# Altium schematic sheet [03]-MCU.SchDoc
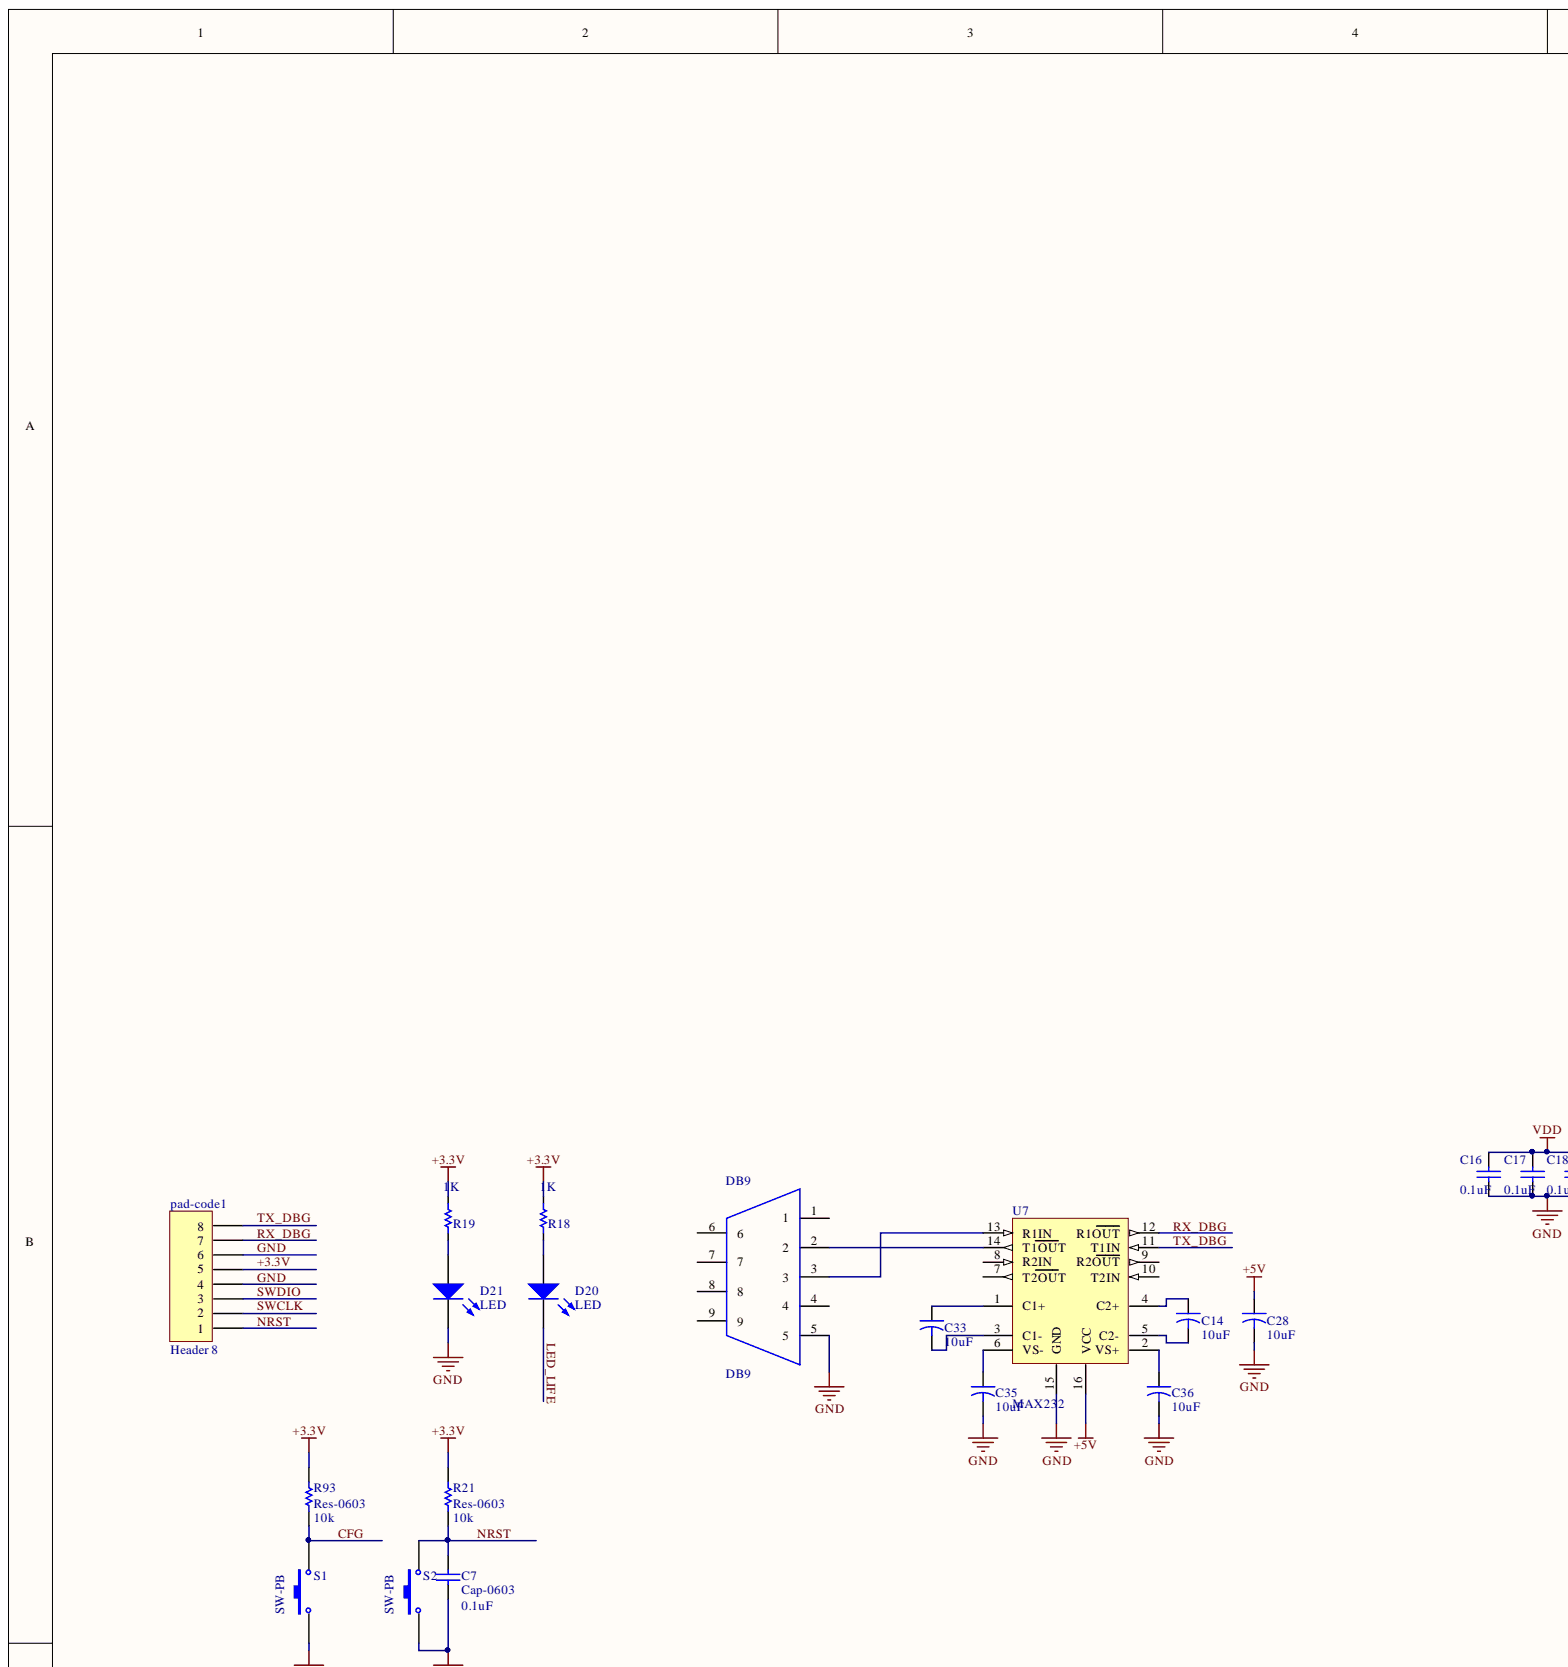
[diagram p0: [03]-MCU.SchDoc, top left]
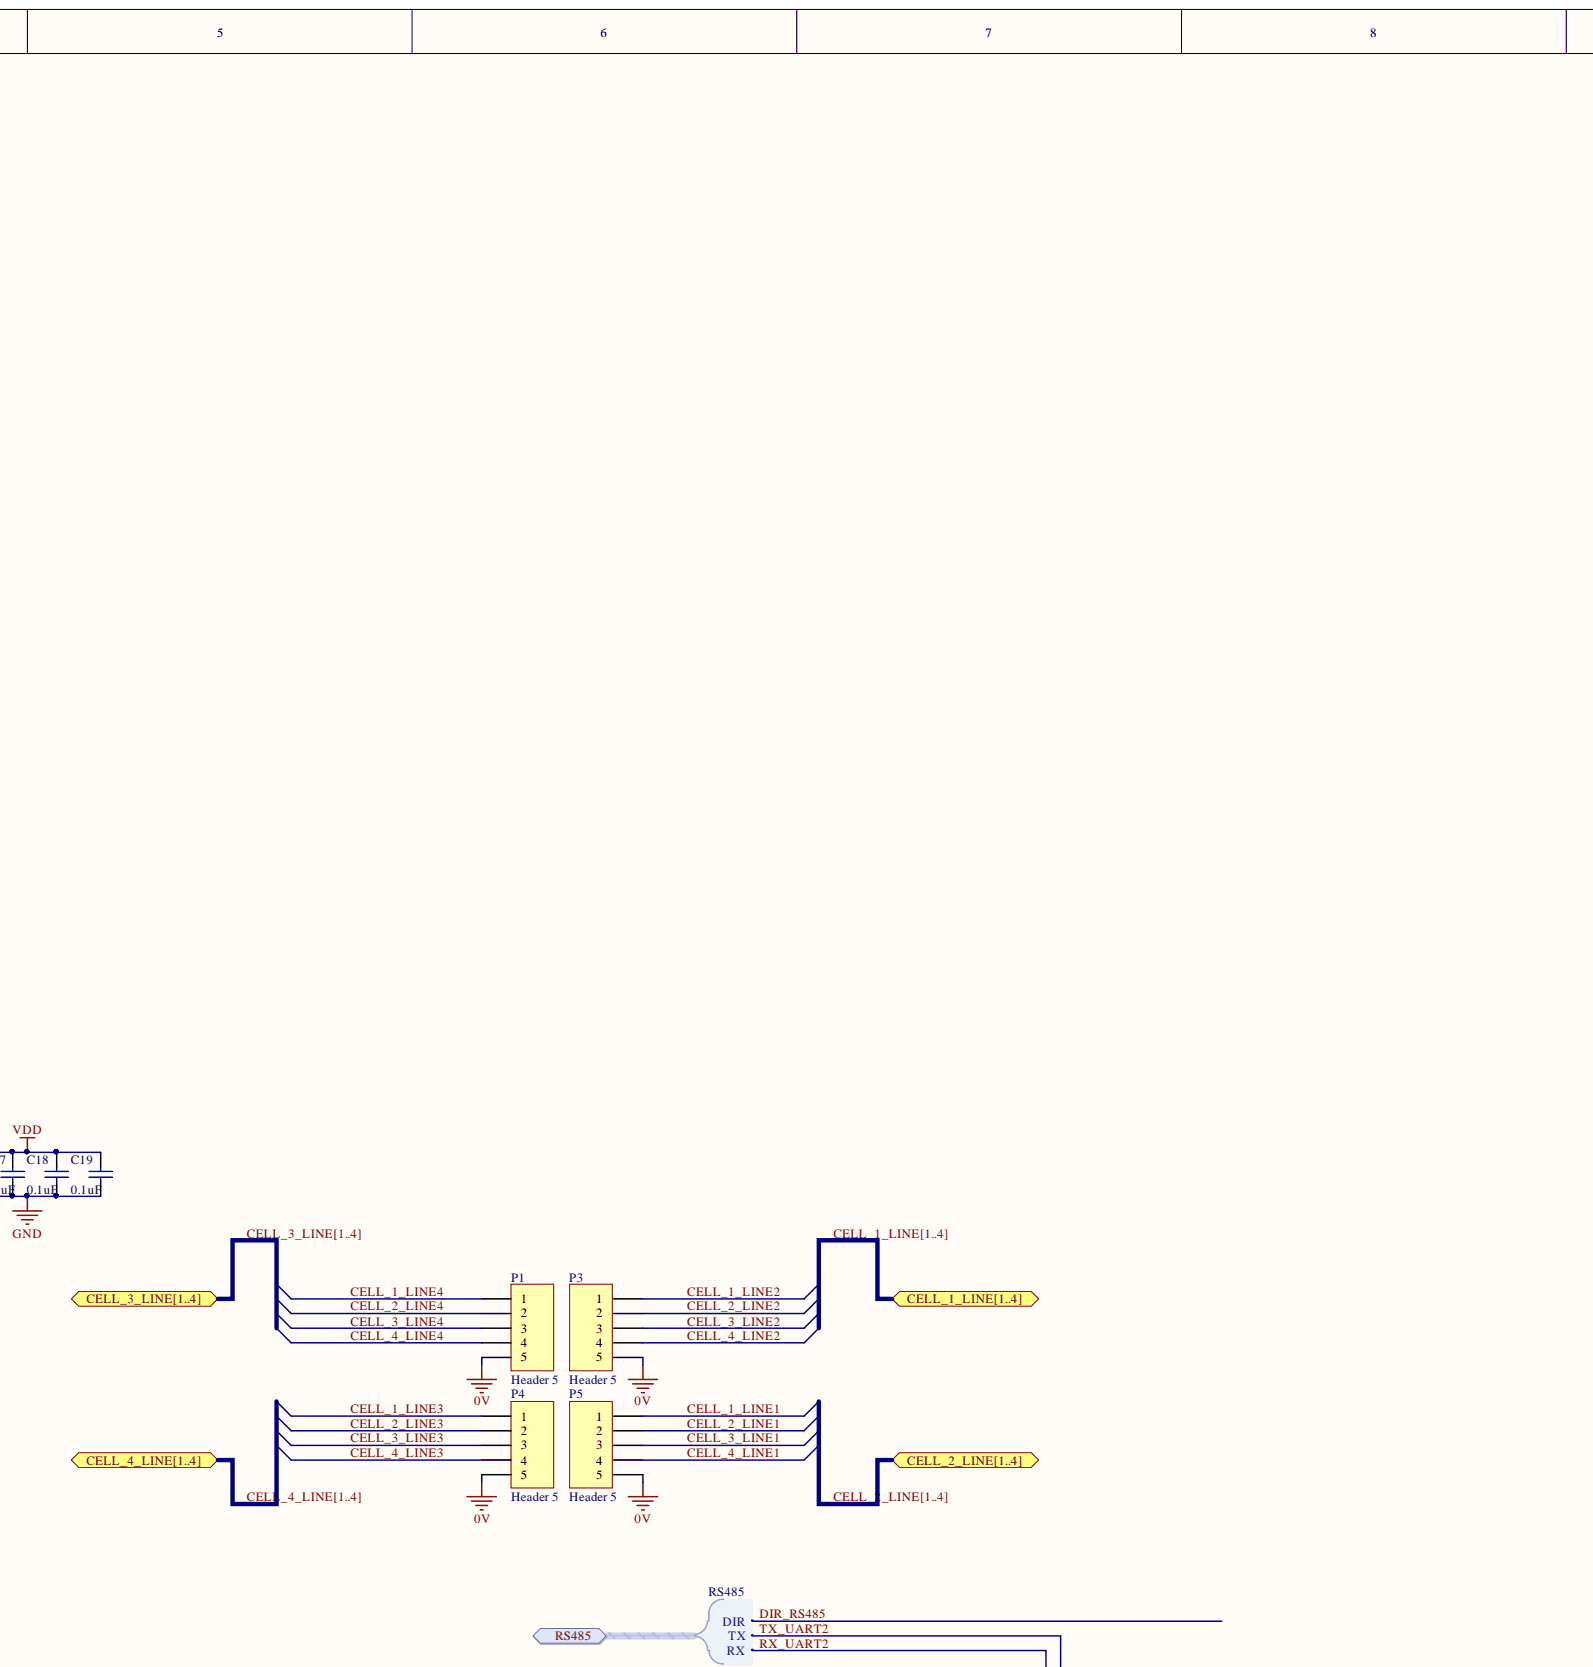
[diagram p1: [03]-MCU.SchDoc, top centre]
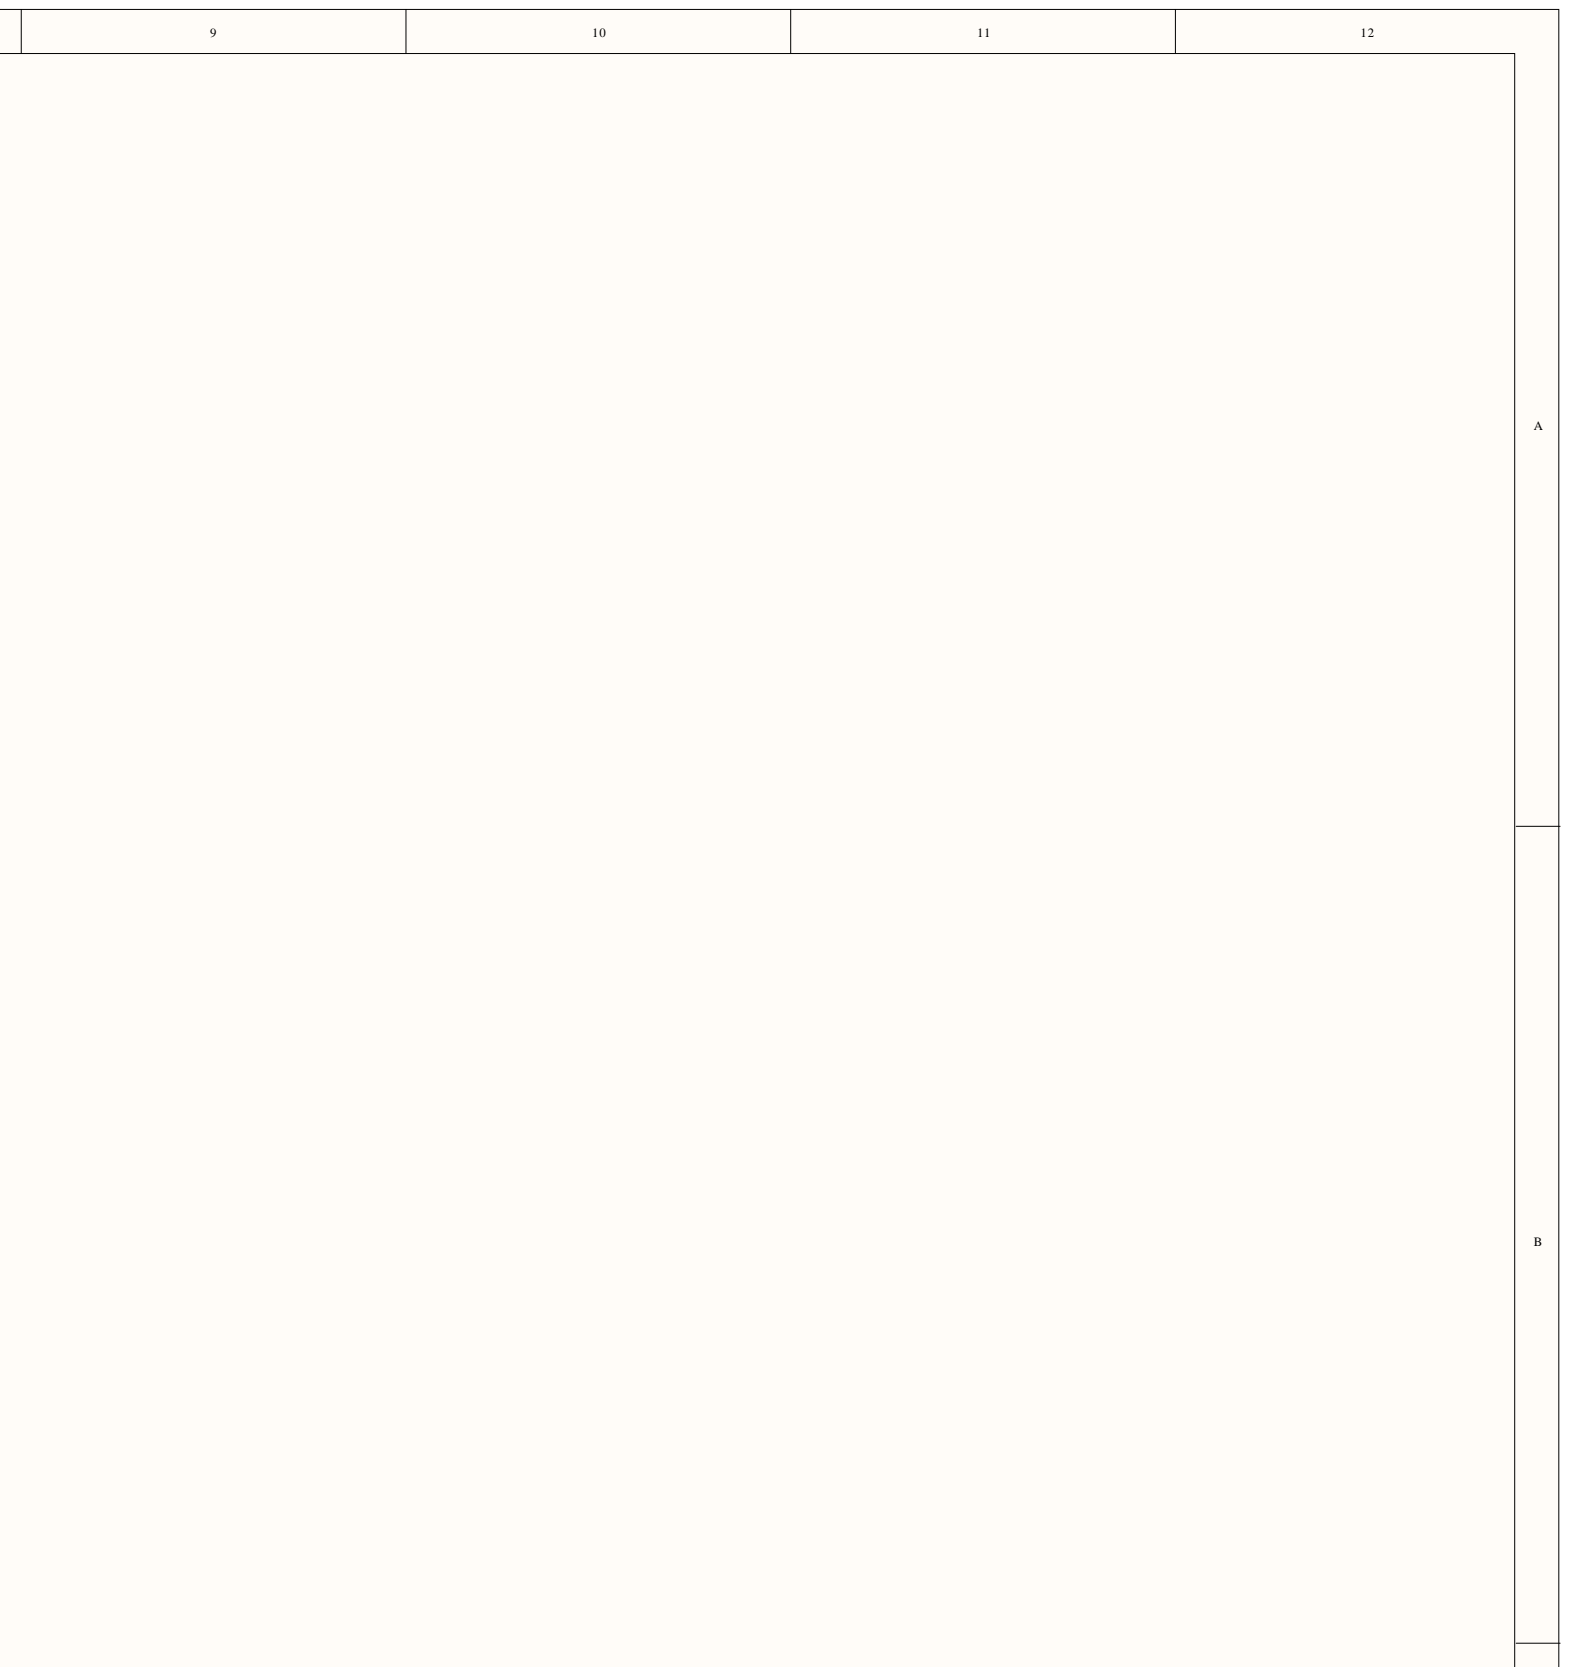
[diagram p2: [03]-MCU.SchDoc, top right]
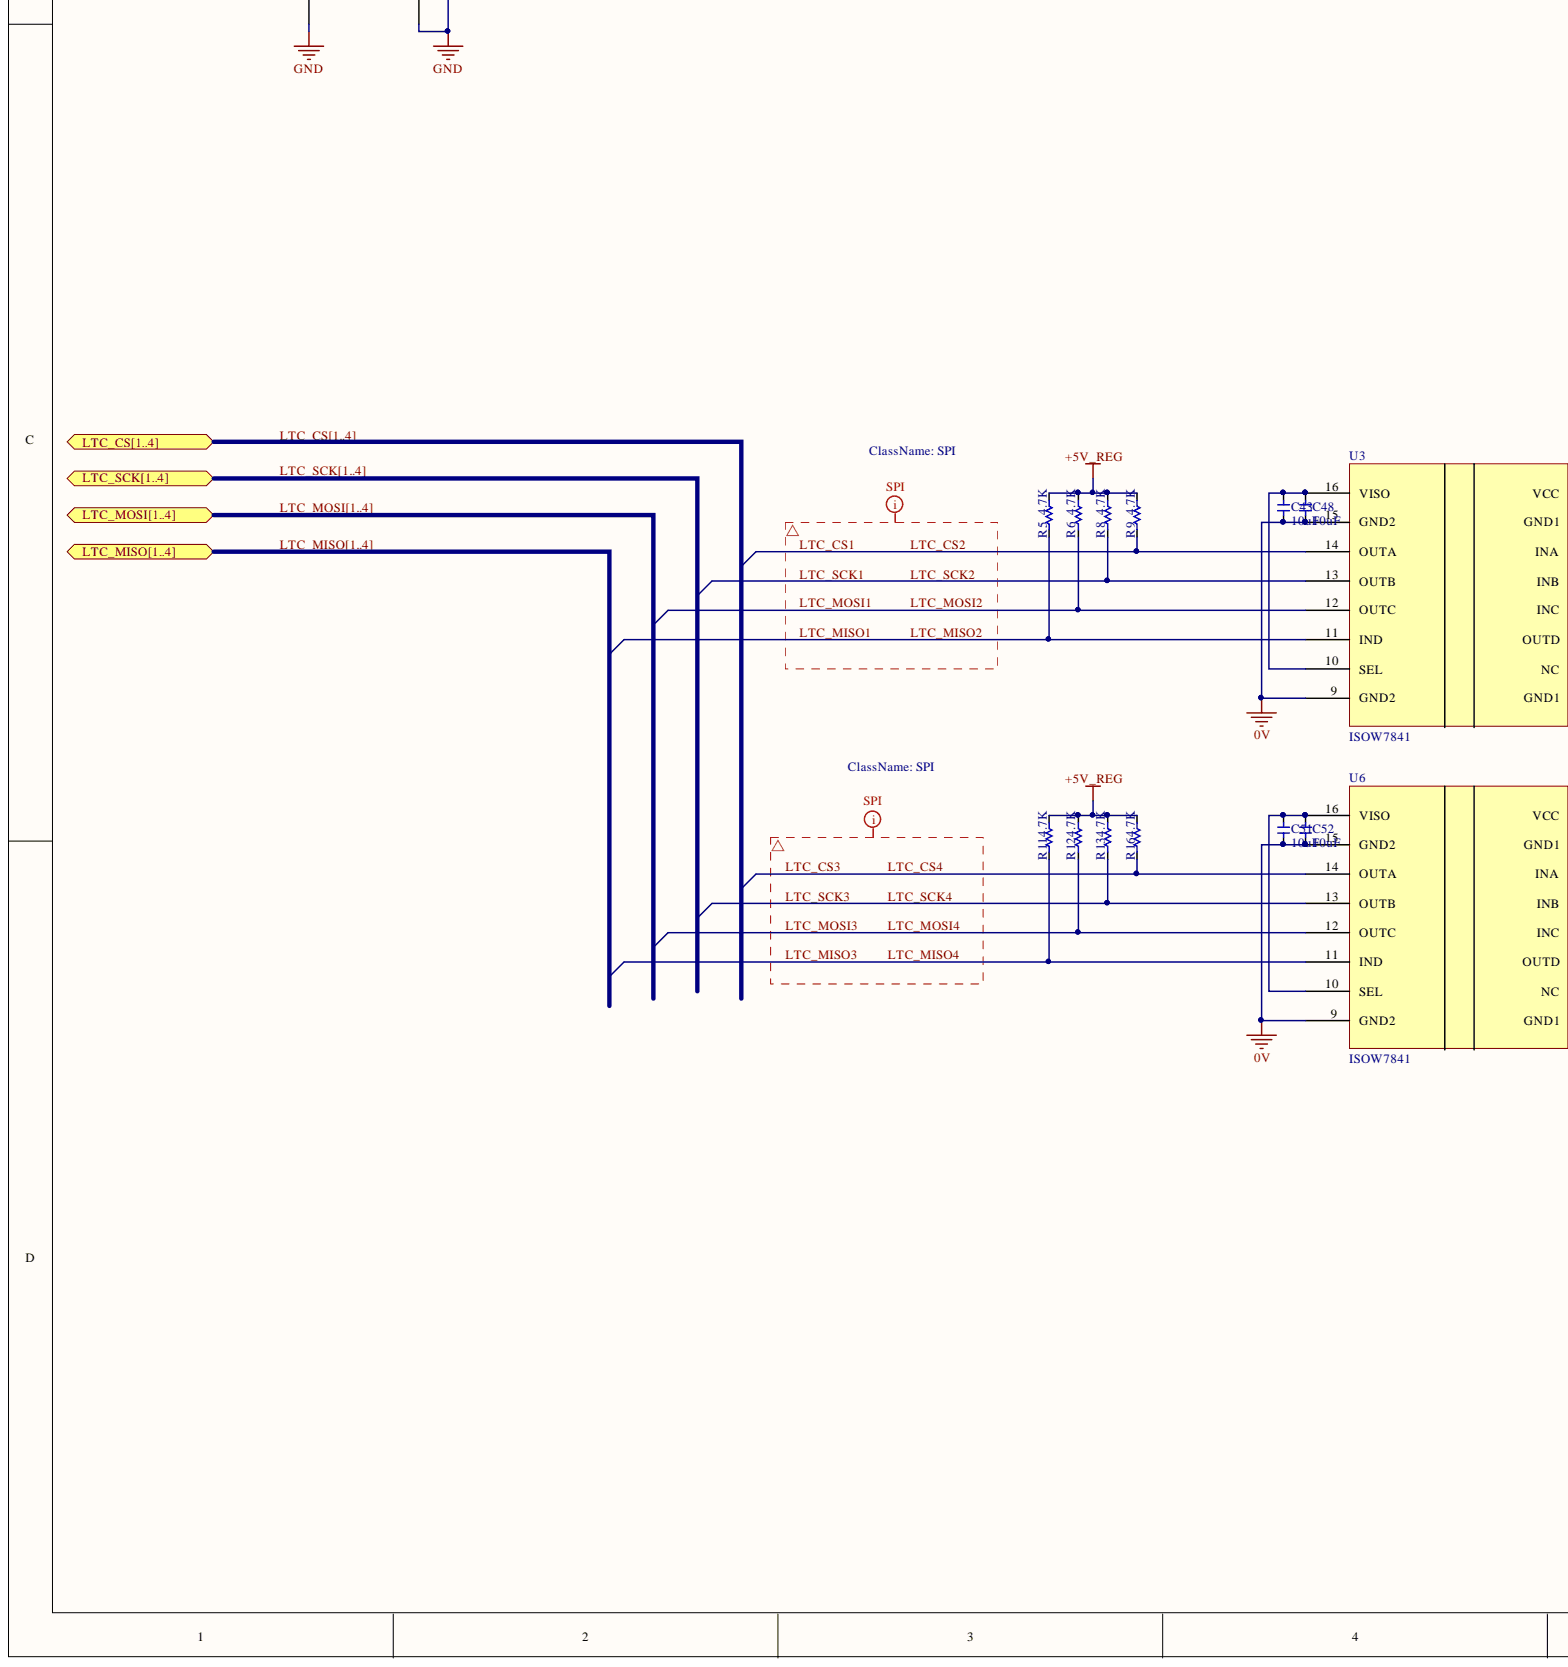
[diagram p3: [03]-MCU.SchDoc, bottom left]
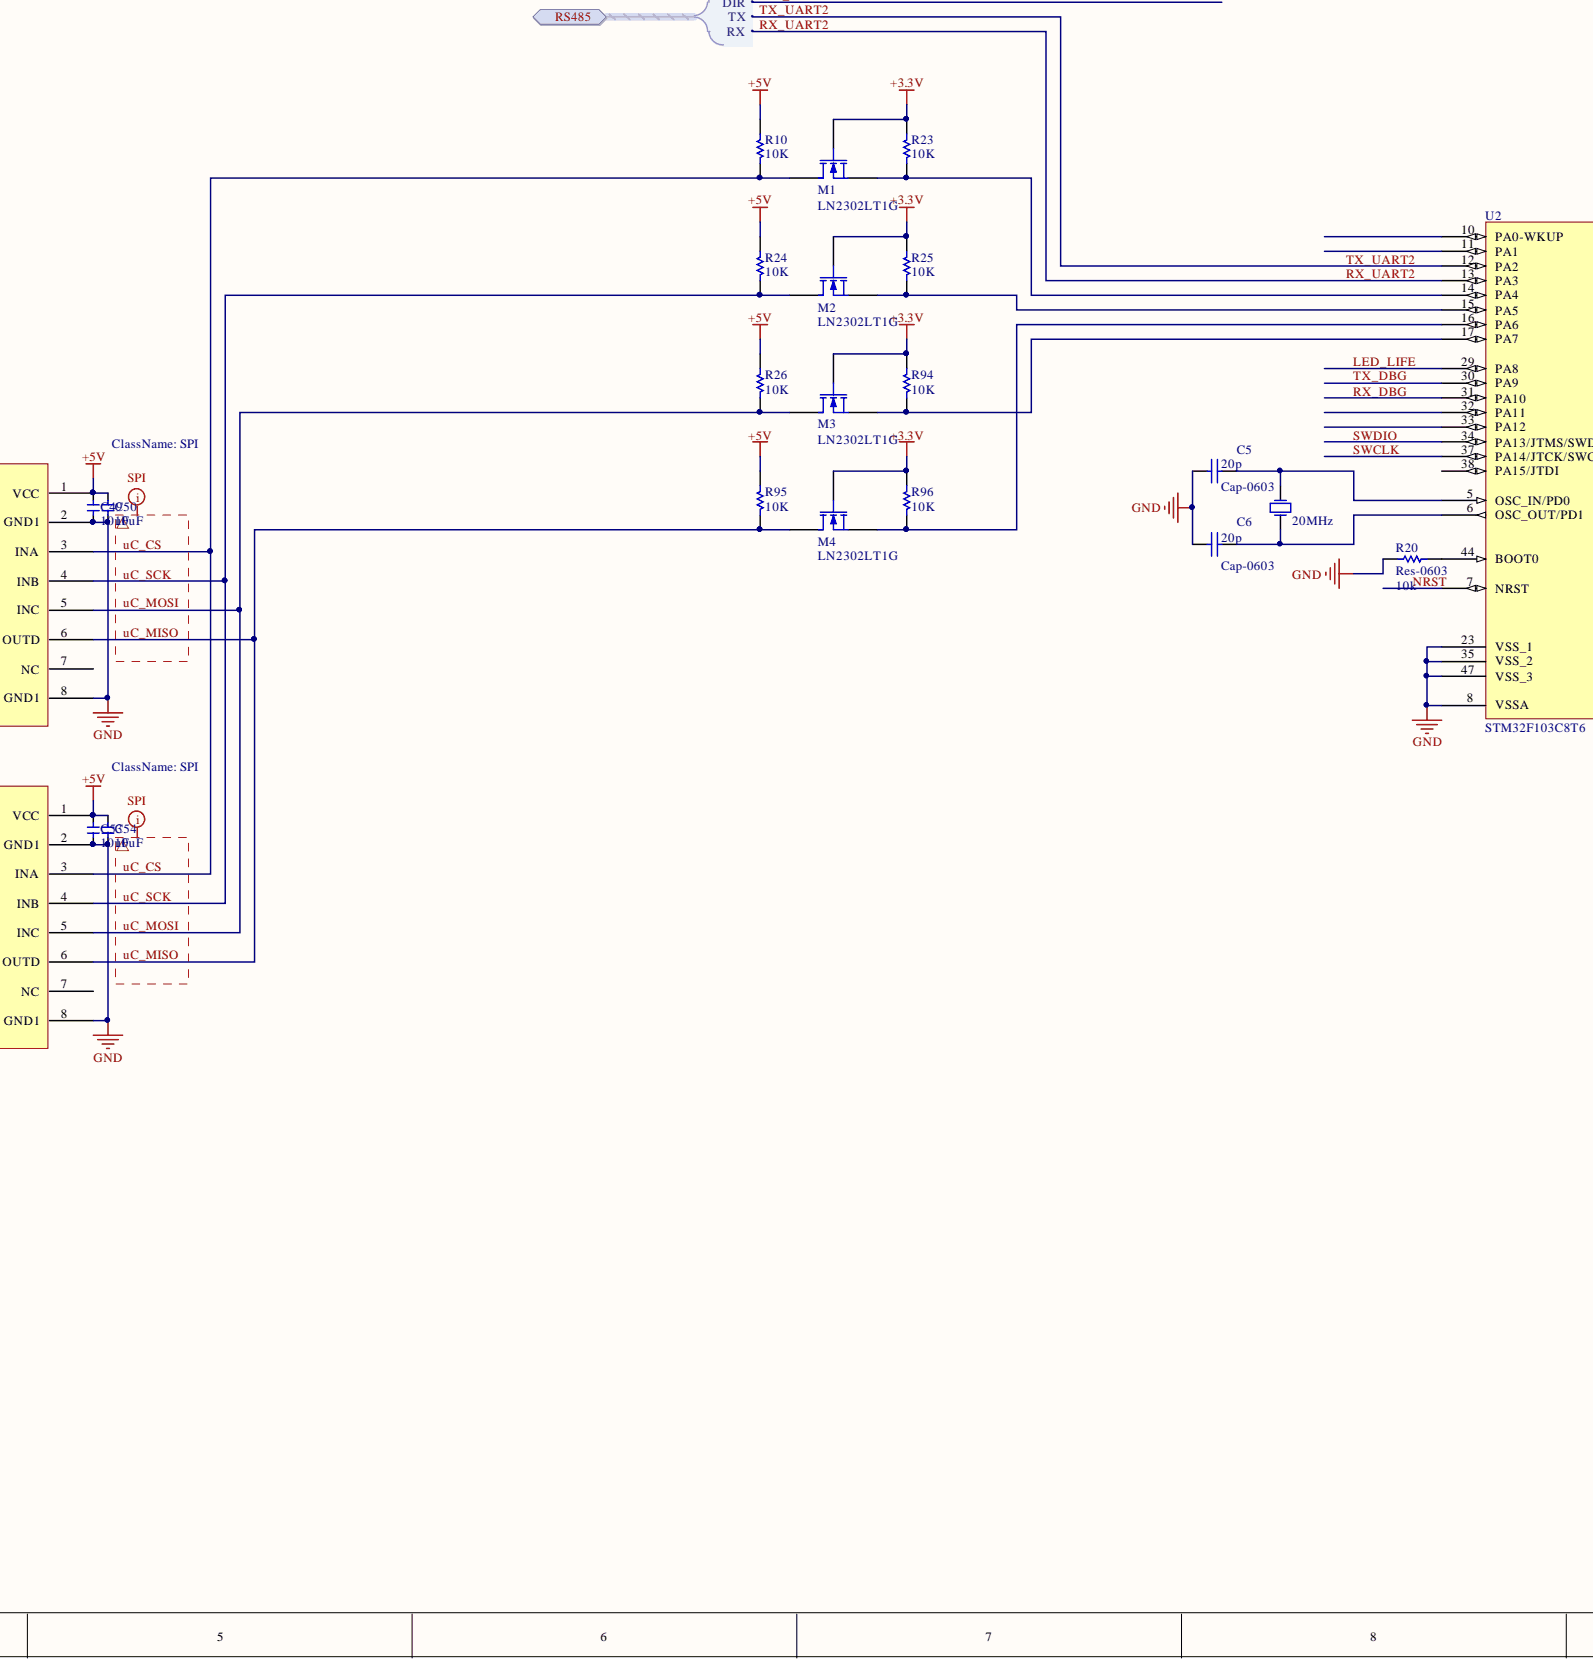
[diagram p4: [03]-MCU.SchDoc, bottom centre]
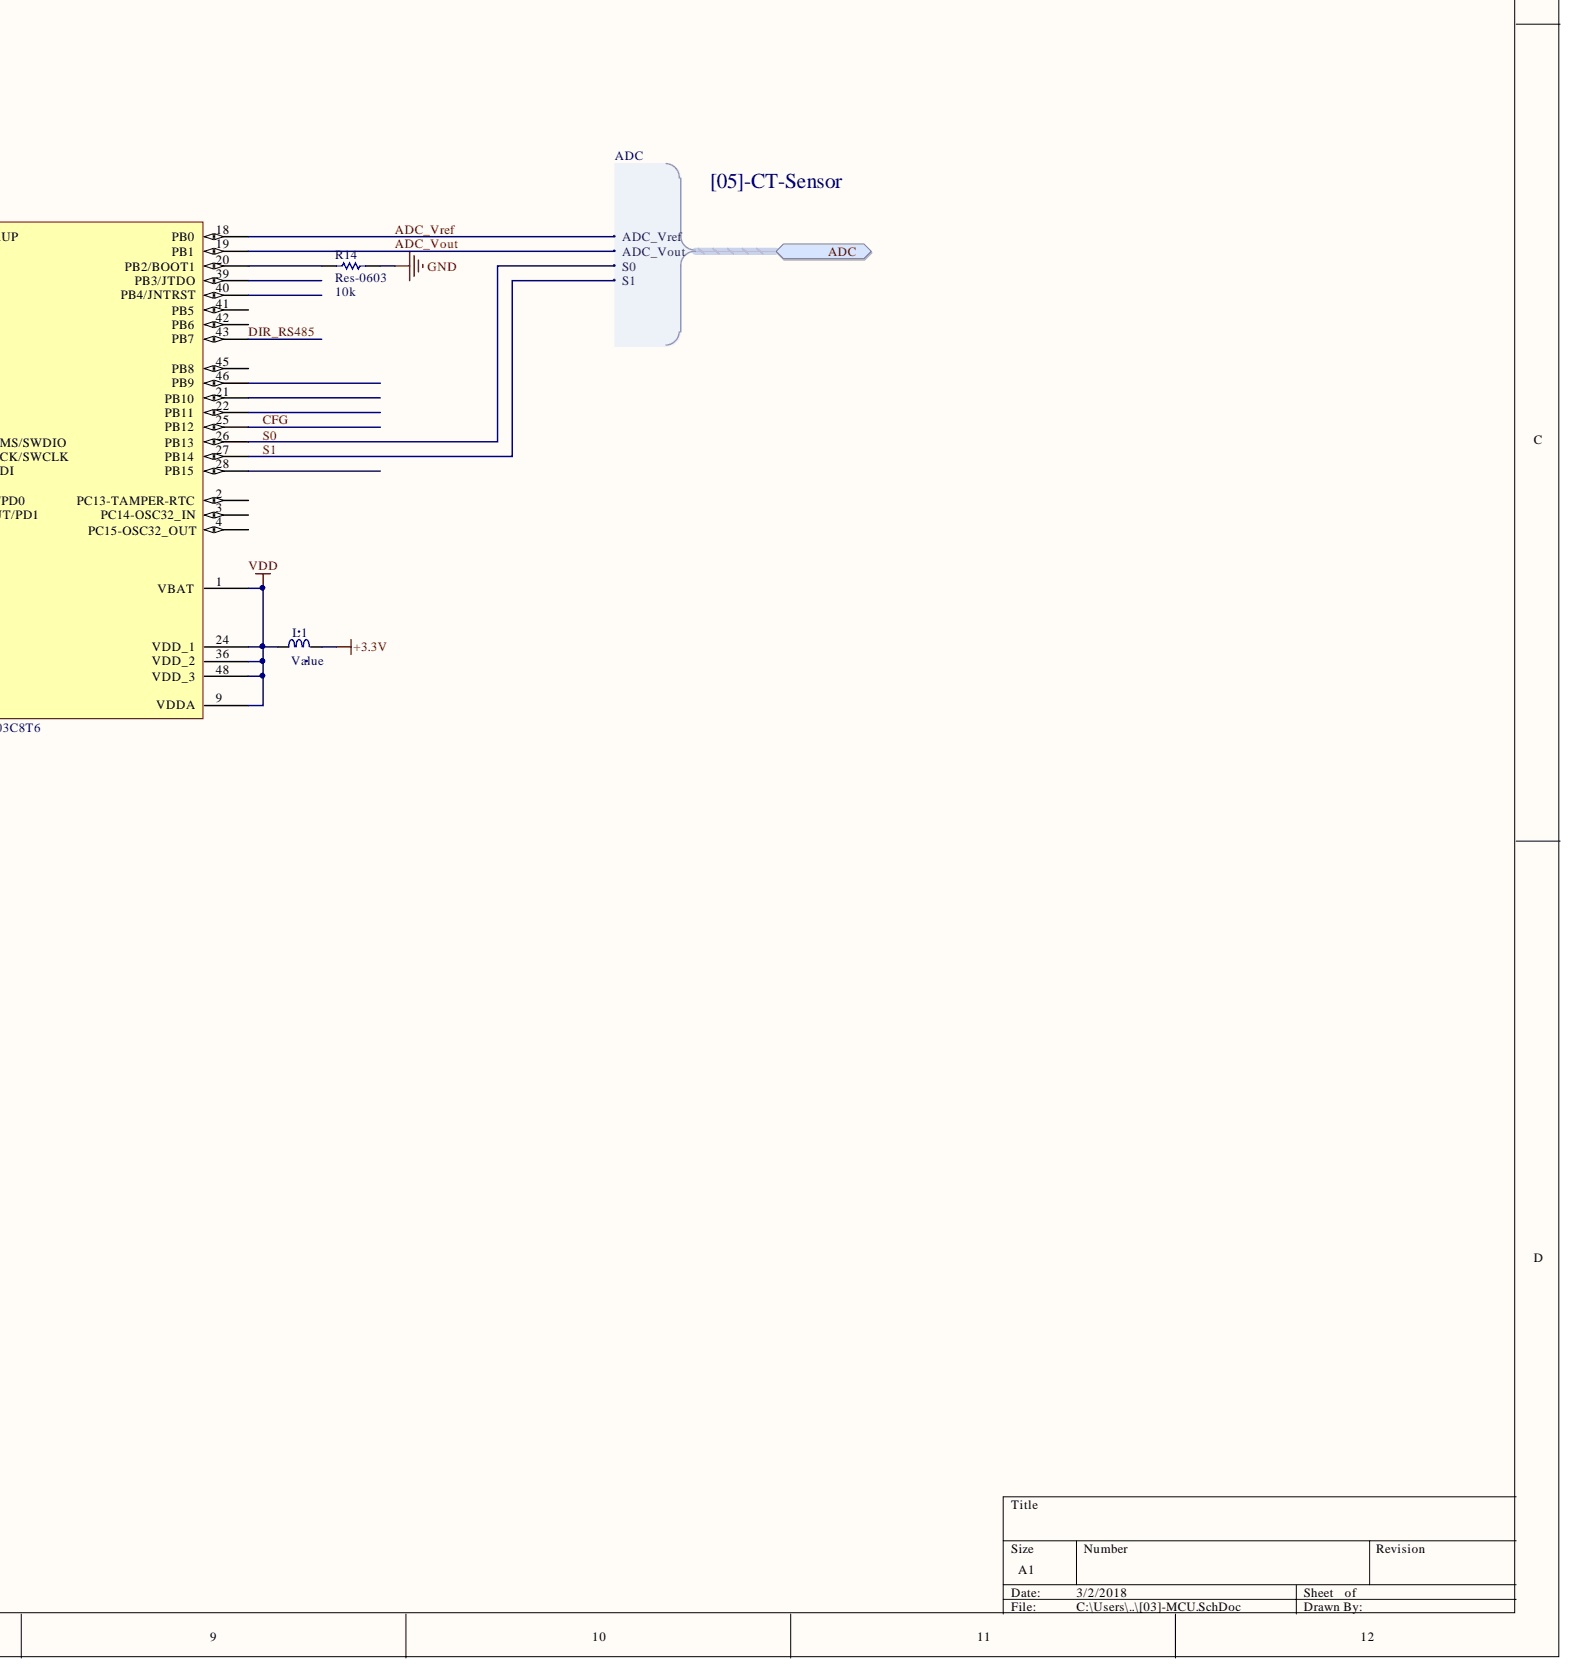
[diagram p5: [03]-MCU.SchDoc, bottom right]

## Parts
C5  Cap-0603  value 20p  [cap-0603]
C6  Cap-0603  value 20p  [cap-0603]
C7  Cap-0603  value 0.1uF  [cap-0603]
C14  10uF  [cap-0805]
C16  value 0.1uF  [cap-0402]  (hidden comment: Cap-0603)
C17  value 0.1uF  [cap-0402]  (hidden comment: Cap-0603)
C18  value 0.1uF  [cap-0402]  (hidden comment: Cap-0603)
C19  value 0.1uF  [cap-0402]  (hidden comment: Cap-0603)
C28  10uF  [cap-0805]
C33  10uF  [cap-0805]
C35  10uF  [cap-0805]
C36  10uF  [cap-0805]
C43  10uF  [cap-0603]
C48  10uF  [cap-0603]
C49  10uF  [cap-0603]
C50  10uF  [cap-0603]
C51  10uF  [cap-0603]
C52  10uF  [cap-0603]
C53  10uF  [cap-0603]
C54  10uF  [cap-0603]
D20  LED  [LED_SMD_0805_BLUE]
D21  LED  [LED_SMD_0805_BLUE]
DB9  DB9  [DB9]
L1  Value  [Inductor-1206]
M1  LN2302LT1G  [SOT-23B_L]
M2  LN2302LT1G  [SOT-23B_L]
M3  LN2302LT1G  [SOT-23B_L]
M4  LN2302LT1G  [SOT-23B_L]
P1  Header 5  [TBlock5-5.08]
P3  Header 5  [TBlock5-5.08]
P4  Header 5  [TBlock5-5.08]
P5  Header 5  [TBlock5-5.08]
pad-code1  Header 8  [HDR1X8]
R5  4.7K  [res-0603]
R6  4.7K  [res-0603]
R8  4.7K  [res-0603]
R9  4.7K  [res-0603]
R10  value 10K  [res-0603]  (hidden comment: Res-0603)
R11  4.7K  [res-0603]  (value not drawn)
R12  4.7K  [res-0603]  (value not drawn)
R13  4.7K  [res-0603]  (value not drawn)
R14  Res-0603  value 10k  [res-0603]
R16  4.7K  [res-0603]  (value not drawn)
R18  1K  [res-0603]
R19  1K  [res-0603]
R20  Res-0603  value 10k  [res-0603]
R21  Res-0603  value 10k  [res-0603]
R23  value 10K  [res-0603]  (hidden comment: Res-0603)
R24  value 10K  [res-0603]  (hidden comment: Res-0603)
R25  value 10K  [res-0603]  (hidden comment: Res-0603)
R26  value 10K  [res-0603]  (hidden comment: Res-0603)
R93  Res-0603  value 10k  [res-0603]
R94  value 10K  [res-0603]  (hidden comment: Res-0603)
R95  value 10K  [res-0603]  (hidden comment: Res-0603)
R96  value 10K  [res-0603]  (hidden comment: Res-0603)
S1  SW-PB  [SW-RST]
S2  SW-PB  [SW-RST]
U2  STM32F103C8T6  [STM-LQFP48_N]
U3  ISOW7841  [ISOW7841]
U6  ISOW7841  [ISOW7841]
U7  MAX232  [SO16]
Y1  20MHz  [XTAL1140X470X160N]

## Ports
ADC, ADC.ADC_VOUT, ADC.ADC_VREF, ADC.S0, ADC.S1, CELL_1_LINE1, CELL_1_LINE2, CELL_1_LINE3, CELL_1_LINE4, CELL_1_LINE[1..4], CELL_2_LINE1, CELL_2_LINE2, CELL_2_LINE3, CELL_2_LINE4, CELL_2_LINE[1..4], CELL_3_LINE1, CELL_3_LINE2, CELL_3_LINE3, CELL_3_LINE4, CELL_3_LINE[1..4], CELL_4_LINE1, CELL_4_LINE2, CELL_4_LINE3, CELL_4_LINE4, CELL_4_LINE[1..4], LTC_CS1, LTC_CS2, LTC_CS3, LTC_CS4, LTC_CS[1..4], LTC_MISO1, LTC_MISO2, LTC_MISO3, LTC_MISO4, LTC_MISO[1..4], LTC_MOSI1, LTC_MOSI2, LTC_MOSI3, LTC_MOSI4, LTC_MOSI[1..4], LTC_SCK1, LTC_SCK2, LTC_SCK3, LTC_SCK4, LTC_SCK[1..4], RS485, RS485.DIR, RS485.RX, RS485.TX

## Nets
0V: C43.1 C48.1 C51.1 C52.1 P1.5 P3.5 P4.5 P5.5 U3.9(GND2) U3.15(GND2) U6.9(GND2) U6.15(GND2)
+5V: C28.1 C49.2 C50.2 C53.2 C54.2 R10.2 R24.2 R26.2 R95.2 U3.1(VCC) U6.1(VCC) U7.16(VCC)
+5V_REG: R5.2 R6.2 R8.2 R9.2 R11.2 R12.2 R13.2 R16.2
VDD: C16.2 C17.2 C18.2 C19.2 L1.1 U2.1(VBAT) U2.9(VDDA) U2.24(VDD_1) U2.36(VDD_2) U2.48(VDD_3)
+3.3V: L1.2 M1.1 M2.1 M3.1 M4.1 pad-code1.5 R18.2 R19.2 R21.1 R23.2 R25.2 R93.1 R94.2 R96.2
ADC_VOUT: U2.19(PB1) ; port ADC
ADC_VREF: U2.18(PB0) ; port ADC
CELL_1_LINE1: P5.1 ; port CELL_1_LINE[1..4]  [also labelled CELL_1_LINE]
CELL_1_LINE2: P3.1 ; port CELL_1_LINE[1..4]  [also labelled CELL_1_LINE]
CELL_1_LINE3: P4.1 ; port CELL_1_LINE[1..4]  [also labelled CELL_1_LINE]
CELL_1_LINE4: P1.1 ; port CELL_1_LINE[1..4]  [also labelled CELL_1_LINE]
CELL_2_LINE1: P5.2 ; port CELL_2_LINE[1..4]  [also labelled CELL_2_LINE]
CELL_2_LINE2: P3.2 ; port CELL_2_LINE[1..4]  [also labelled CELL_2_LINE]
CELL_2_LINE3: P4.2 ; port CELL_2_LINE[1..4]  [also labelled CELL_2_LINE]
CELL_2_LINE4: P1.2 ; port CELL_2_LINE[1..4]  [also labelled CELL_2_LINE]
CELL_3_LINE1: P5.3 ; port CELL_3_LINE[1..4]  [also labelled CELL_3_LINE]
CELL_3_LINE2: P3.3 ; port CELL_3_LINE[1..4]  [also labelled CELL_3_LINE]
CELL_3_LINE3: P4.3 ; port CELL_3_LINE[1..4]  [also labelled CELL_3_LINE]
CELL_3_LINE4: P1.3 ; port CELL_3_LINE[1..4]  [also labelled CELL_3_LINE]
CELL_4_LINE1: P5.4 ; port CELL_4_LINE[1..4]  [also labelled CELL_4_LINE]
CELL_4_LINE2: P3.4 ; port CELL_4_LINE[1..4]  [also labelled CELL_4_LINE]
CELL_4_LINE3: P4.4 ; port CELL_4_LINE[1..4]  [also labelled CELL_4_LINE]
CELL_4_LINE4: P1.4 ; port CELL_4_LINE[1..4]  [also labelled CELL_4_LINE]
CFG: R93.2 S1.2 U2.25(PB12)
DIR_RS485: U2.43(PB7) ; port RS485
GND: C5.1 C6.1 C7.1 C16.1 C17.1 C18.1 C19.1 C28.2 C35.2 C36.2 C49.1 C50.1 C53.1 C54.1 D21.2 DB9.5 pad-code1.4 pad-code1.6 R14.2 R20.2 S1.1 S2.1 U2.8(VSSA) U2.23(VSS_1) U2.35(VSS_2) U2.47(VSS_3) U3.2(GND1) U3.8(GND1) U6.2(GND1) U6.8(GND1) U7.15(GND)
LED_LIFE: D20.2 U2.29(PA8)
LTC_CS2: R9.1 U3.14(OUTA) ; port LTC_CS[1..4]  [also labelled LTC_CS]
LTC_CS4: R16.1 U6.14(OUTA) ; port LTC_CS[1..4]  [also labelled LTC_CS]
LTC_MISO2: R5.1 U3.11(IND) ; port LTC_MISO[1..4]  [also labelled LTC_MISO]
LTC_MISO4: R11.1 U6.11(IND) ; port LTC_MISO[1..4]  [also labelled LTC_MISO]
LTC_MOSI2: R6.1 U3.12(OUTC) ; port LTC_MOSI[1..4]  [also labelled LTC_MOSI]
LTC_MOSI4: R12.1 U6.12(OUTC) ; port LTC_MOSI[1..4]  [also labelled LTC_MOSI]
LTC_SCK2: R8.1 U3.13(OUTB) ; port LTC_SCK[1..4]  [also labelled LTC_SCK]
LTC_SCK4: R13.1 U6.13(OUTB) ; port LTC_SCK[1..4]  [also labelled LTC_SCK]
NRST: C7.2 pad-code1.1 R21.2 S2.2 U2.7(NRST)
RX_DBG: pad-code1.7 U2.31(PA10) U7.12(R1OUT)
RX_UART2: U2.13(PA3) ; port RS485
S0: U2.26(PB13) ; port ADC
S1: U2.27(PB14) ; port ADC
SWCLK: pad-code1.2 U2.37(PA14/JTCK/SWCLK)
SWDIO: pad-code1.3 U2.34(PA13/JTMS/SWDIO)
TX_DBG: pad-code1.8 U2.30(PA9) U7.11(T1IN)
TX_UART2: U2.12(PA2) ; port RS485
UC_CS: M1.3 R10.1 U3.3(INA) U6.3(INA)
UC_MISO: M4.3 R95.1 U3.6(OUTD) U6.6(OUTD)
UC_MOSI: M3.3 R26.1 U3.5(INC) U6.5(INC)
UC_SCK: M2.3 R24.1 U3.4(INB) U6.4(INB)
NetC5_2: C5.2 U2.5(OSC_IN/PD0) Y1.2
NetC6_2: C6.2 U2.6(OSC_OUT/PD1) Y1.1
NetC14_1: C14.1 U7.4(C2+)
NetC14_2: C14.2 U7.5(C2-)
NetC33_1: C33.1 U7.1(C1+)
NetC33_2: C33.2 U7.3(C1-)
NetC35_1: C35.1 U7.6(VS-)
NetC36_1: C36.1 U7.2(VS+)
NetC43_2: C43.2 C48.2 U3.10(SEL) U3.16(VISO)
NetC51_2: C51.2 C52.2 U6.10(SEL) U6.16(VISO)
NetD20_1: D20.1 R18.1
NetD21_1: D21.1 R19.1
NetDB9_2: DB9.2 U7.14(T1OUT)
NetDB9_3: DB9.3 U7.13(R1IN)
NetM1_2: M1.2 R23.1 U2.14(PA4)
NetM2_2: M2.2 R25.1 U2.15(PA5)
NetM3_2: M3.2 R94.1 U2.17(PA7)
NetM4_2: M4.2 R96.1 U2.16(PA6)
NetR14_1: R14.1 U2.20(PB2/BOOT1)
NetR20_1: R20.1 U2.44(BOOT0)
N00429:
N00430:
N00431:
N00432:

Not connected: DB9 1 4 6 7 8 9; U2 2(PC13-TAMPER-RTC) 3(PC14-OSC32_IN) 4(PC15-OSC32_OUT) 10(PA0-WKUP) 11(PA1) 21(PB10) 22(PB11) 28(PB15) 32(PA11) 33(PA12) 38(PA15/JTDI) 39(PB3/JTDO) 40(PB4/JNTRST) 41(PB5) 42(PB6) 45(PB8) 46(PB9); U3 7(NC); U6 7(NC); U7 7(T2OUT) 8(R2IN) 9(R2OUT) 10(T2IN)

## Library data of the parts
C5-C7 C16-C19 C43 C48-C54 = Cap-0603: Capacitor (Semiconductor SIM Model); Component Kind: Standard; LatestRevisionDate: 29-May-2009; LatestRevisionNote: IPC-7351 Footprint Added.; PackageDescription: Chip Capacitor; PackageReference: C1206; Published: 8-Jun-2000; Publisher: Altium Limited
  C5-C7: Library Name: PCB_Project.IntLib
  C16-C19: Library Name: Devices.SchLib
  C43 C48-C54: Library Name: THUVIENTUTAO.lib
C14 C28 C33 C35 C36 = Cap2: Capacitor; Component Kind: Standard; PackageDescription: Capacitor; 2 Leads; PackageReference: CAPR5-4X5; Published: 23-Sep-2002; Signal Integrity: Cap; Simulation: CAP; Library Name: THUVIENTUTAO.lib; Publisher: Altium Limited
D20 D21 = LED: Typical INFRARED GaAs LED; Component Kind: Standard; LatestRevisionDate: 08-Jul-2005; LatestRevisionNote: Mech Layer 1 removed.; PackageReference: LED-0; Published: 8-Jun-2000; Library Name: THUVIENTUTAO.lib; Publisher: Altium Limited
L1 = Inductor: Component Kind: Standard; Description: Inductor; Library Name: SCH_NHATBON.SchLib
M1-M4 = mosfet_kenh_n: Component Kind: Standard; Library Name: THUVIENTUTAO.lib
P1 P3-P5 = Header 5: Header, 5-Pin; Component Kind: Standard; LatestRevisionDate: 17-Jul-2002; LatestRevisionNote: Re-released for DXP Platform.; Library Name: THUVIENTUTAO.lib; Publisher: Altium Limited
pad-code1 = Header 8: Header, 8-Pin; Component Kind: Standard; LatestRevisionDate: 17-Jul-2002; LatestRevisionNote: Re-released for DXP Platform.; Library Name: THUVIENTUTAO.lib; Publisher: Altium Limited
R5 R6 R8-R14 R16 R18-R21 R23-R26 R93-R96 = Res-0603: Resistor; Component Kind: Standard; LatestRevisionDate: 17-Jul-2002; LatestRevisionNote: Re-released for DXP Platform.; PackageDescription: Axial Device, Thru-Hole; 2 Leads; 0.3 in Pin Spacing; PackageReference: AXIAL-0.3; Published: 8-Jun-2000; Publisher: Altium Limited
  R10 R23-R26 R94-R96: Library Name: Devices.SchLib
  R14 R20 R21 R93: Library Name: PCB_Project.IntLib
  R5 R6 R8 R9 R11-R13 R16 R18 R19: Library Name: THUVIENTUTAO.lib
S1 S2 = SW-PB: Switch; Component Kind: Standard; LatestRevisionDate: 17-Jul-2002; LatestRevisionNote: Re-released for DXP Platform.; PackageReference: SPST-2; Published: 24-Mar-1999; Library Name: THUVIENTUTAO.lib; Publisher: Altium Limited
U2 = STM32F103C8T6: STM32 ARM-based 32-bit MCU with 64 Kbytes Flash, 48-pin LQFP, Industrial Temperature; Component Kind: Standard; ComponentLink1Description: Manufacturer Link; ComponentLink1URL: www.st.com/stm32; ComponentLink2Description: Datasheet; ComponentLink2URL: http://www.st.com/stonline/products/literature/ds/13587.pdf; DatasheetVersion: rev6, Mar-2008; PackageDescription: 48-Pin Low-Profile Quad Flat Pack, 7 x 7 mm Body, 0.5 mm Pitch (LQFP48); PackageReference: LQFP48; PackageVersion: Mar-2008; Published: 30-May-2008; Library Name: IOT_DAT.SchLib; Publisher: Altium Limited
U7 = MAX232_1: RS232 LEVEL SHIFTER; Manufacturer: Texas Instruments; Manufacturer Part Number: MAX232DR; Number of Drivers/Receivers: 2/2; Voltage - Supply: 4.5 V ~ 5.5 V; Category: Integrated Circuits (ICs); Component Kind: Standard; Package / Case: 16-SOIC (3.9mm Width); Packaging: Cut Tape (CT); Protocol: RS232; RoHS: RoHS Compliant; Supplier: Digi-Key; Supplier 1: Digi-Key; Supplier Part Number: 296-14619-1-ND; Supplier Part Number 1: 296-14619-1-ND; Type: Transceiver; Library Name: THUVIENTUTAO.lib
Y1 = XTAL: Crystal Oscillator; Component Kind: Standard; LatestRevisionDate: 17-Jul-2002; LatestRevisionNote: Re-released for DXP Platform.; PackageReference: R38; Published: 8-Jun-2000; Library Name: SCH_NHATBON.SchLib; Publisher: Altium Limited
DB9 = DB9: Component Kind: Standard; Library Name: THUVIENTUTAO.lib
U3 U6 = ISOW7841: Component Kind: Standard; Library Name: THUVIENTUTAO.lib
